# Altium schematic sheet SLA-1500-FFC.SchDoc
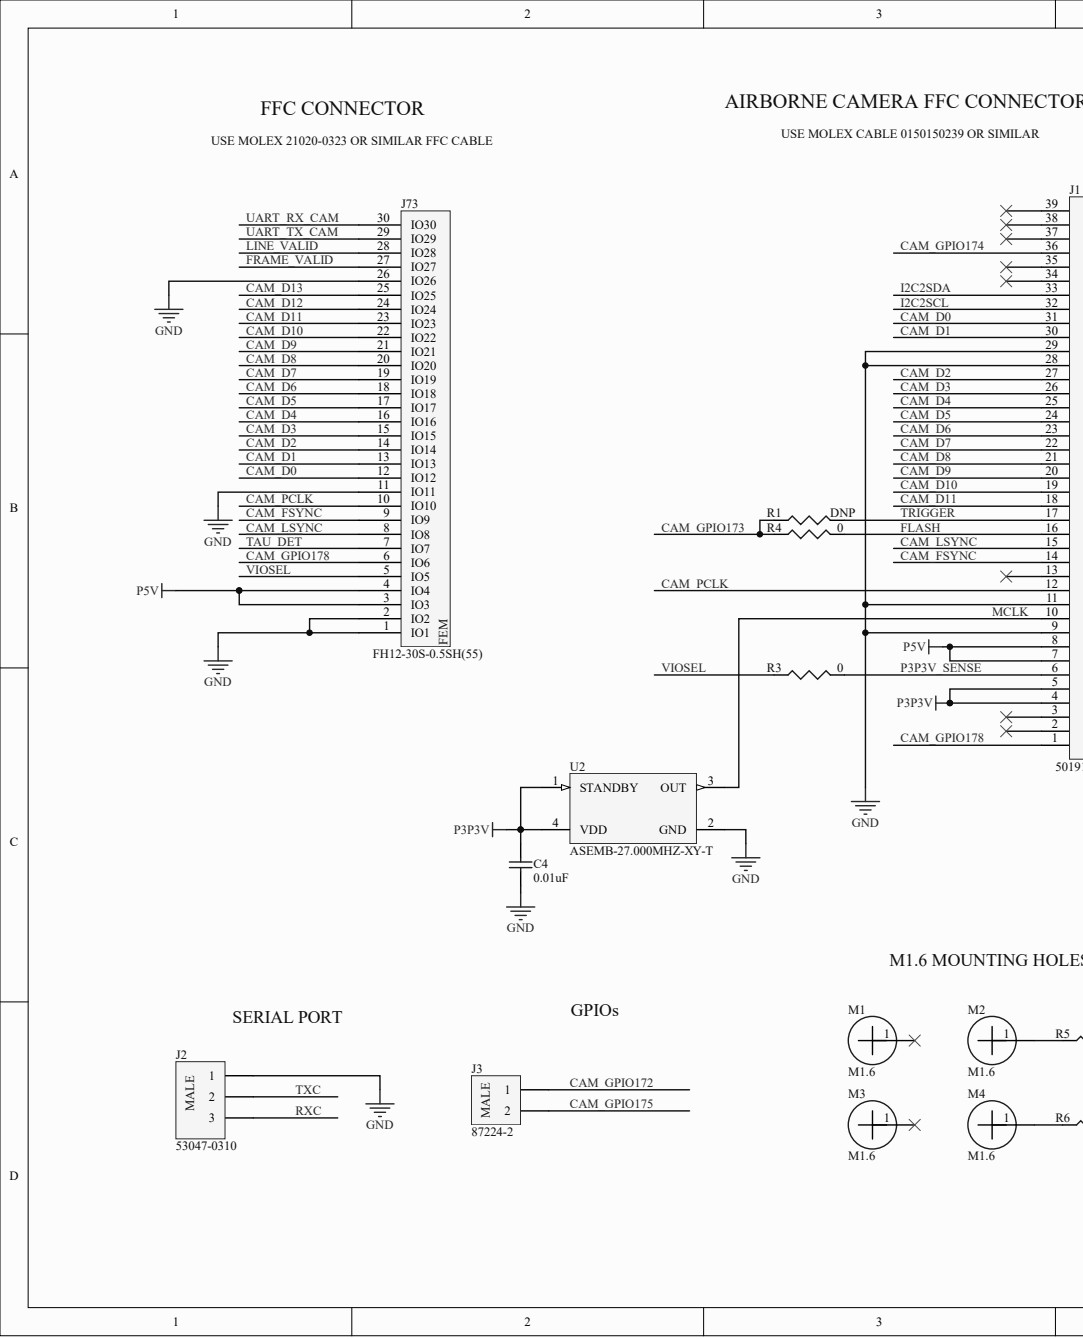
[diagram p0: SLA-1500-FFC.SchDoc, left]
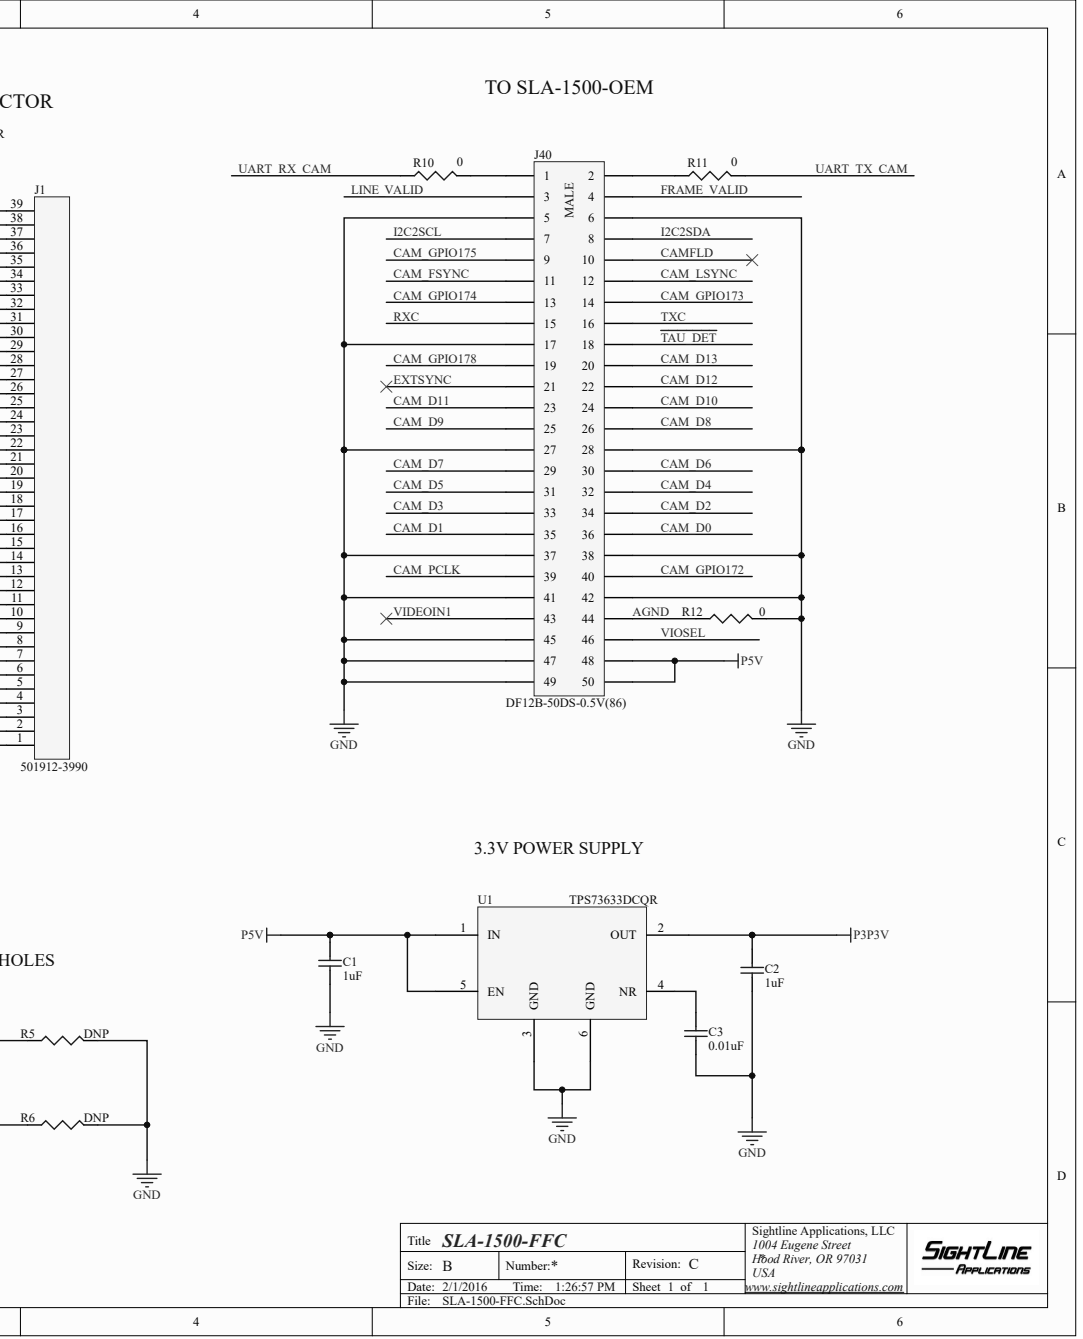
[diagram p1: SLA-1500-FFC.SchDoc, right]

## Parts
C1  1uF  [0402]
C2  1uF  [0402]
C3  0.01uF  [0402]
C4  0.01uF  [0402]
J1  501912-3990
J2  53047-0310
J3  87224-2
J40  DF12B-50DS-0.5V(86)
J73  FH12-30S-0.5SH(55)
M1  M1.6
M2  M1.6
M3  M1.6
M4  M1.6
R1  DNP  [0402]
R3  0  [0402]
R4  0  [0402]
R5  DNP  [0402]
R6  DNP  [0402]
R10  0  [0603]
R11  0  [0603]
R12  0  [0603]
U1  TPS73633DCQR
U2  ASEMB-27.000MHZ-XY-T

## Nets
GND: C1.1 C2.1 C3.1 C4.1 J1.9 J1.11 J1.28 J1.29 J2.1 J40.5 J40.6 J40.17 J40.27 J40.28 J40.37 J40.38 J40.41 J40.42 J40.45 J40.47 J40.49 J73.1(IO1) J73.2(IO2) J73.11(IO11) J73.26(IO26) R5.2 R6.2 R12.2 U1.3(GND) U1.6(GND) U2.2(GND)
P3P3V: C2.2 C4.2 J1.4 J1.5 U1.2(OUT) U2.1(STANDBY) U2.4(VDD)
P5V: C1.2 J1.7 J1.8 J40.48 J40.50 J73.3(IO3) J73.4(IO4) U1.1(IN) U1.5(EN)
AGND: J40.44 R12.1
CAM_D0: J1.31 J40.36 J73.12(IO12)
CAM_D1: J1.30 J40.35 J73.13(IO13)
CAM_D2: J1.27 J40.34 J73.14(IO14)
CAM_D3: J1.26 J40.33 J73.15(IO15)
CAM_D4: J1.25 J40.32 J73.16(IO16)
CAM_D5: J1.24 J40.31 J73.17(IO17)
CAM_D6: J1.23 J40.30 J73.18(IO18)
CAM_D7: J1.22 J40.29 J73.19(IO19)
CAM_D8: J1.21 J40.26 J73.20(IO20)
CAM_D9: J1.20 J40.25 J73.21(IO21)
CAM_D10: J1.19 J40.24 J73.22(IO22)
CAM_D11: J1.18 J40.23 J73.23(IO23)
CAM_D12: J40.22 J73.24(IO24)
CAM_D13: J40.20 J73.25(IO25)
CAM_FSYNC: J1.14 J40.11 J73.9(IO9)
CAM_GPIO172: J3.1 J40.40
CAM_GPIO173: J40.14 R1.1 R4.1
CAM_GPIO174: J1.36 J40.13
CAM_GPIO175: J3.2 J40.9
CAM_GPIO178: J1.1 J40.19 J73.6(IO6)
CAM_LSYNC: J1.15 J40.12 J73.8(IO8)
CAM_PCLK: J1.12 J40.39 J73.10(IO10)
CAMFLD: J40.10
EXTSYNC: J40.21
FLASH: J1.16 R4.2
FRAME_VALID: J40.4 J73.27(IO27)
I2C2SCL: J1.32 J40.7
I2C2SDA: J1.33 J40.8
LINE_VALID: J40.3 J73.28(IO28)
MCLK: J1.10 U2.3(OUT)
P3P3V_SENSE: J1.6 R3.2
RXC: J2.3 J40.15
~{TAU_DET}: J40.18 J73.7(IO7)
TRIGGER: J1.17 R1.2
TXC: J2.2 J40.16
UART_RX_CAM: J73.30(IO30) R10.1
UART_TX_CAM: J73.29(IO29) R11.2
VIDEOIN1: J40.43
VIOSEL: J40.46 J73.5(IO5) R3.1
NetC3_2: C3.2 U1.4(NR)
NetJ40_1: J40.1 R10.2
NetJ40_2: J40.2 R11.1
NetM2_1: M2.1 R5.1
NetM4_1: M4.1 R6.1

Not connected: J1 2 3 13 34 35 37 38 39; M1 1; M3 1

## Library data of the parts
C1 C2 = (no symbol name): MfgPartNo: GRM155R61A105KE15D; SLA Part No: SL00104; Rated Voltage: 10V; Tolerance: 10%; Type: Ceramic - X5R; Mfg: Murata; Value: 1uF
C3 C4 = (no symbol name): MfgPartNo: EMK063BJ103KP-F; SLA Part No: SL00107; Rated Voltage: 16V; Tolerance: 10%; Type: Ceramic - X5R; Value: 0.01uF
J1 = (no symbol name): Female/Male: Female; Mfg: Molex; MfgPartNo: 501912-3990; Mounting Style: SMT
J2 = (no symbol name): Female/Male: Male; Mfg: Molex; Mounting Style: Thru-Hole; HelpURL: http://www.digikey.com/product-detail/en/0530470310/WM1732-ND/242854; MfgPartNo: 53047-0310
J3 = (no symbol name): SLA Part No: SL00311; Female/Male: Male; Mfg: TE; HelpURL: http://www.te.com/commerce/DocumentDelivery/DDEController?Action=srchrtrv&DocNm=87224&DocType=Customer+Drawing&DocLang=English; MfgPartNo: 87224-2; Mounting Style: Thru-Hole
J40 = (no symbol name): SLA Part No: SL00297; Female/Male: Male; Mfg: Hirose; MfgPartNo: DF12B-50DS-0.5V(86); HelpURL: http://www.hirose.co.jp/cataloge_hp/e53700036.pdf; Mounting Style: SMT
J73 = (no symbol name): Female/Male: Female; Mfg: Hirose; HelpURL: http://www.hirose.co.jp/cataloge_hp/e58605370.pdf; MfgPartNo: FH12-30S-0.5SH(55); Mounting Style: SMT
M1-M4 = (no symbol name): Mfg: NA; MfgPartNo: M1.6
R1 R5 R6 = (no symbol name): Mfg: DNI; MfgPartNo: DNI
R3 R4 = (no symbol name): MfgPartNo: ERJ-2GE0R00X; SLA Part No: SL00128; Power (W): 1/10; Mfg: Panasonic; Value: 0; Tolerance: -
R10-R12 = (no symbol name): MfgPartNo: ERJ-3GEY0R00V; SLA Part No: SL00033; Mfg: Panasonic; Power (W): 1/10; Tolerance: -; Value: 0
U1 = (no symbol name): Mfg: Texas Instruments; MfgPartNo: TPS73633DCQR; Package: SOT-223-6 (TI DCQ); HelpURL: http://www.ti.com/lit/ds/symlink/tps73601.pdf
U2 = (no symbol name): Mfg: Abracon; Frequency: 27MHz; MfgPartNo: ASEMB-27.000MHZ-XY-T; Package: ASEMB; Datasheet: http://www.digikey.com/product-detail/en/ASEMB-27.000MHZ-XY-T/535-11127-1-ND/2624353
